# Altium schematic sheet LOGIC_FPGA.SchDoc
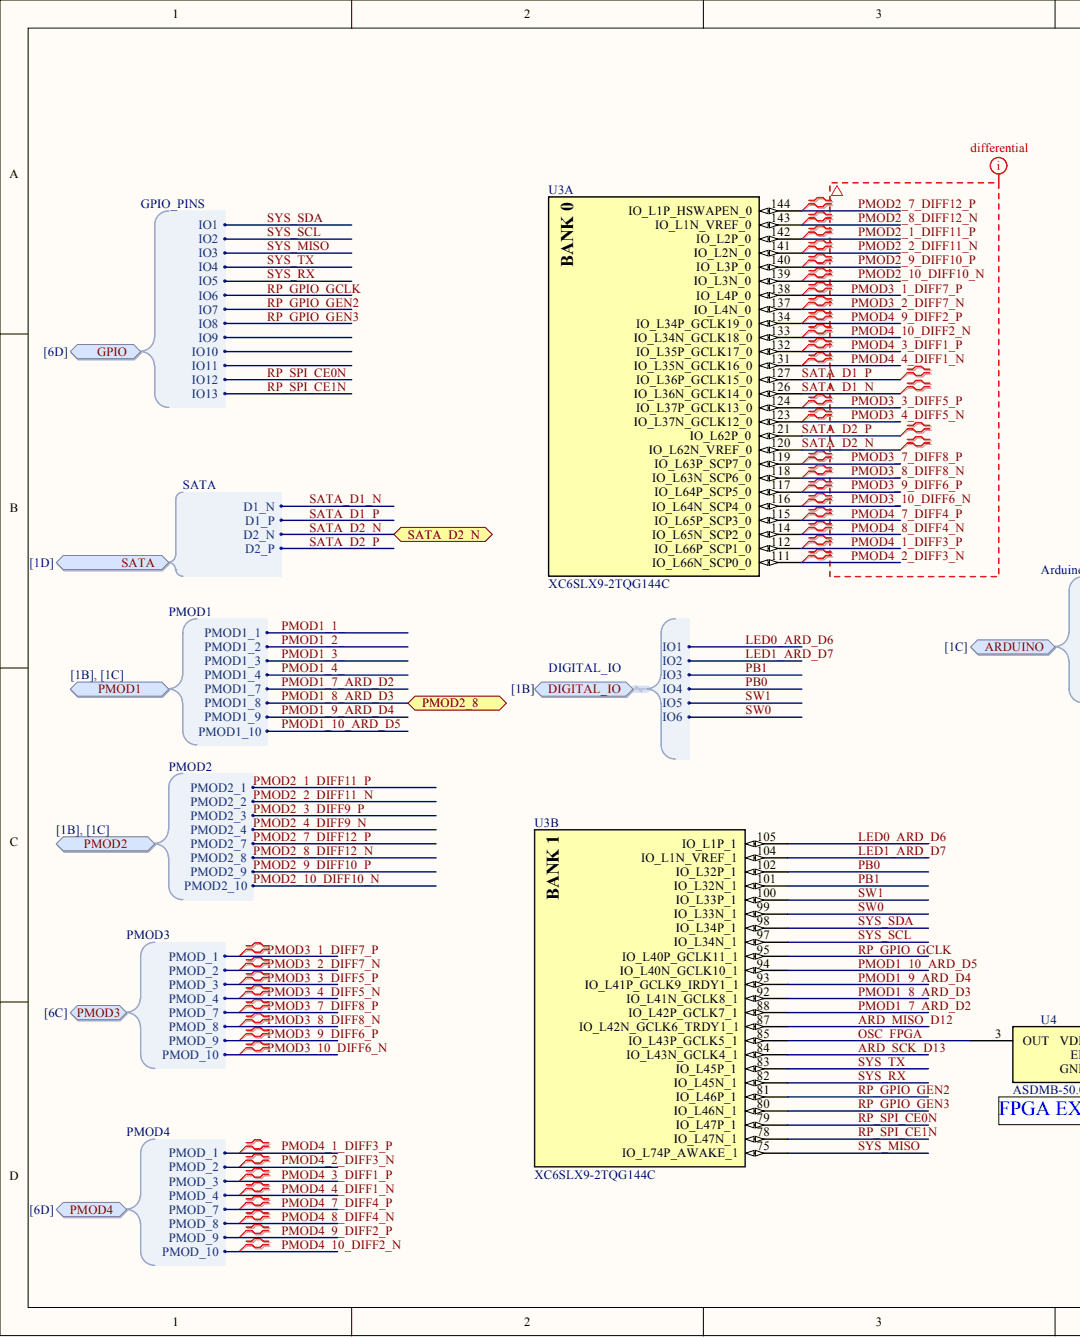
[diagram p0: LOGIC_FPGA.SchDoc, left]
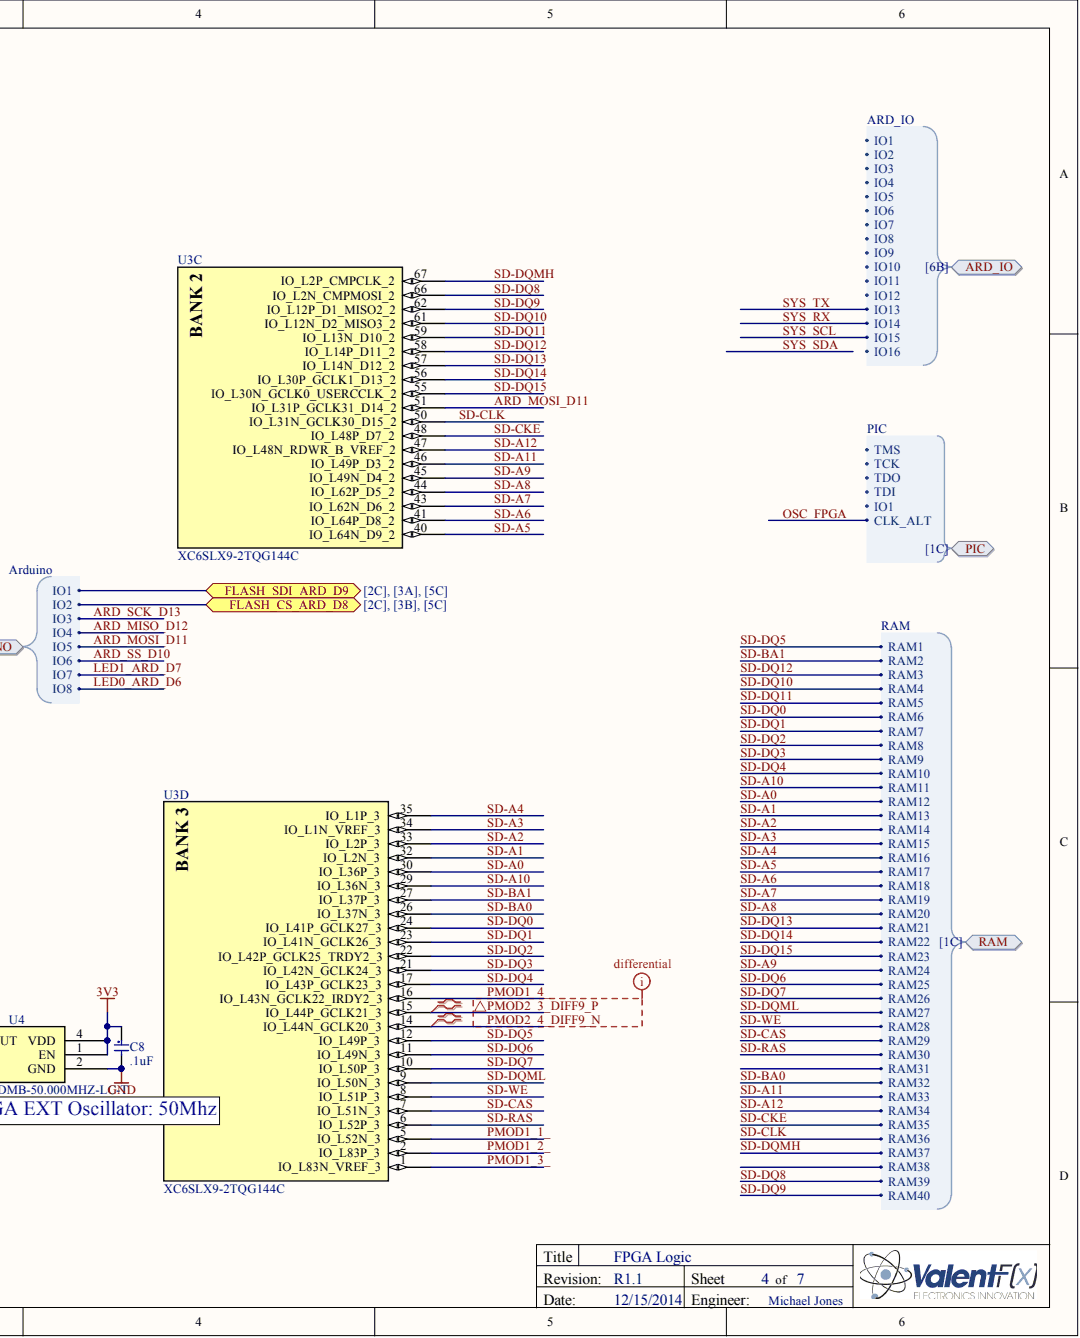
[diagram p1: LOGIC_FPGA.SchDoc, right]

## Parts
C8  .1uF  [c0603]
U3  XC6SLX9-2TQG144C  [TQ144_L]  (parts A,B,C,D)
U4  ASDMB-50.000MHZ-LC-T  [ASDMB - osc]

## Ports
ARD_IO, ARD_IO.IO1, ARD_IO.IO2, ARD_IO.IO3, ARD_IO.IO4, ARD_IO.IO5, ARD_IO.IO6, ARD_IO.IO7, ARD_IO.IO8, ARD_IO.IO9, ARD_IO.IO10, ARD_IO.IO11, ARD_IO.IO12, ARD_IO.IO13, ARD_IO.IO14, ARD_IO.IO15, ARD_IO.IO16, ARDUINO, ARDUINO.IO1, ARDUINO.IO2, ARDUINO.IO3, ARDUINO.IO4, ARDUINO.IO5, ARDUINO.IO6, ARDUINO.IO7, ARDUINO.IO8, DIGITAL_IO, DIGITAL_IO.IO1, DIGITAL_IO.IO2, DIGITAL_IO.IO3, DIGITAL_IO.IO4, DIGITAL_IO.IO5, DIGITAL_IO.IO6, FLASH_CS_ARD_D8, FLASH_SDI_ARD_D9, GPIO, GPIO.IO1, GPIO.IO2, GPIO.IO3, GPIO.IO4, GPIO.IO5, GPIO.IO6, GPIO.IO7, GPIO.IO8, GPIO.IO9, GPIO.IO10, GPIO.IO11, GPIO.IO12, GPIO.IO13, PIC, PIC.CLK_ALT, PIC.IO1, PIC.TCK, PIC.TDI, PIC.TDO, PIC.TMS, PMOD1, PMOD1.PMOD1_1, PMOD1.PMOD1_2, PMOD1.PMOD1_3, PMOD1.PMOD1_4, PMOD1.PMOD1_7, PMOD1.PMOD1_8, PMOD1.PMOD1_9, PMOD1.PMOD1_10, PMOD2, PMOD2.PMOD2_1, PMOD2.PMOD2_2, PMOD2.PMOD2_3, PMOD2.PMOD2_4, PMOD2.PMOD2_7, PMOD2.PMOD2_8, PMOD2.PMOD2_9, PMOD2.PMOD2_10, PMOD2_8, PMOD3, PMOD3.PMOD_1, PMOD3.PMOD_2, PMOD3.PMOD_3, PMOD3.PMOD_4, PMOD3.PMOD_7, PMOD3.PMOD_8, PMOD3.PMOD_9, PMOD3.PMOD_10, PMOD4, PMOD4.PMOD_1, PMOD4.PMOD_2, PMOD4.PMOD_3, PMOD4.PMOD_4, PMOD4.PMOD_7, PMOD4.PMOD_8, PMOD4.PMOD_9, PMOD4.PMOD_10, RAM, RAM.RAM1, RAM.RAM2, RAM.RAM3, RAM.RAM4, RAM.RAM5, RAM.RAM6, RAM.RAM7, RAM.RAM8, RAM.RAM9, RAM.RAM10, RAM.RAM11, RAM.RAM12, RAM.RAM13, RAM.RAM14, RAM.RAM15, RAM.RAM16, RAM.RAM17, RAM.RAM18, RAM.RAM19, RAM.RAM20, RAM.RAM21, RAM.RAM22, RAM.RAM23, RAM.RAM24, RAM.RAM25, RAM.RAM26, RAM.RAM27, RAM.RAM28, RAM.RAM29, RAM.RAM30, RAM.RAM31, RAM.RAM32, RAM.RAM33, RAM.RAM34, RAM.RAM35, RAM.RAM36, RAM.RAM37, RAM.RAM38, RAM.RAM39, RAM.RAM40, SATA, SATA.D1_N, SATA.D1_P, SATA.D2_N, SATA.D2_P, SATA_D2_N

## Nets
3V3: C8.1 U4.1(EN) U4.4(VDD)
GND: C8.2 U4.2(GND)
ARD_MISO_D12: U3.87(IO_L42N_GCLK6_TRDY1_1) ; port ARDUINO
ARD_MOSI_D11: U3.51(IO_L31P_GCLK31_D14_2) ; port ARDUINO
ARD_SCK_D13: U3.84(IO_L43N_GCLK4_1) ; port ARDUINO
LED0_ARD_D6: U3.105(IO_L1P_1) ; port ARDUINO, port DIGITAL_IO
LED1_ARD_D7: U3.104(IO_L1N_VREF_1) ; port ARDUINO, port DIGITAL_IO
OSC_FPGA: U3.85(IO_L43P_GCLK5_1) U4.3(OUT) ; port PIC
PB0: U3.102(IO_L32P_1) ; port DIGITAL_IO
PB1: U3.101(IO_L32N_1) ; port DIGITAL_IO
PMOD1_1_: U3.5(IO_L52N_3) ; port PMOD1
PMOD1_2_: U3.2(IO_L83P_3) ; port PMOD1
PMOD1_3_: U3.1(IO_L83N_VREF_3) ; port PMOD1
PMOD1_4_: U3.16(IO_L43N_GCLK22_IRDY2_3) ; port PMOD1
PMOD1_7_ARD_D2: U3.88(IO_L42P_GCLK7_1) ; port PMOD1
PMOD1_8_ARD_D3: U3.92(IO_L41N_GCLK8_1) ; port PMOD1, port PMOD2_8
PMOD1_9_ARD_D4: U3.93(IO_L41P_GCLK9_IRDY1_1) ; port PMOD1
PMOD1_10_ARD_D5: U3.94(IO_L40N_GCLK10_1) ; port PMOD1
PMOD2_1_DIFF11_P: U3.142(IO_L2P_0) ; port PMOD2
PMOD2_2_DIFF11_N: U3.141(IO_L2N_0) ; port PMOD2
PMOD2_3_DIFF9_P: U3.15(IO_L44P_GCLK21_3) ; port PMOD2
PMOD2_4_DIFF9_N: U3.14(IO_L44N_GCLK20_3) ; port PMOD2
PMOD2_7_DIFF12_P: U3.144(IO_L1P_HSWAPEN_0) ; port PMOD2
PMOD2_8_DIFF12_N: U3.143(IO_L1N_VREF_0) ; port PMOD2
PMOD2_9_DIFF10_P: U3.140(IO_L3P_0) ; port PMOD2
PMOD2_10_DIFF10_N: U3.139(IO_L3N_0) ; port PMOD2
PMOD3_1_DIFF7_P: U3.138(IO_L4P_0) ; port PMOD3
PMOD3_2_DIFF7_N: U3.137(IO_L4N_0) ; port PMOD3
PMOD3_3_DIFF5_P: U3.124(IO_L37P_GCLK13_0) ; port PMOD3
PMOD3_4_DIFF5_N: U3.123(IO_L37N_GCLK12_0) ; port PMOD3
PMOD3_7_DIFF8_P: U3.119(IO_L63P_SCP7_0) ; port PMOD3
PMOD3_8_DIFF8_N: U3.118(IO_L63N_SCP6_0) ; port PMOD3
PMOD3_9_DIFF6_P: U3.117(IO_L64P_SCP5_0) ; port PMOD3
PMOD3_10_DIFF6_N: U3.116(IO_L64N_SCP4_0) ; port PMOD3
PMOD4_1_DIFF3_P: U3.112(IO_L66P_SCP1_0) ; port PMOD4
PMOD4_2_DIFF3_N: U3.111(IO_L66N_SCP0_0) ; port PMOD4
PMOD4_3_DIFF1_P: U3.132(IO_L35P_GCLK17_0) ; port PMOD4
PMOD4_4_DIFF1_N: U3.131(IO_L35N_GCLK16_0) ; port PMOD4
PMOD4_7_DIFF4_P: U3.115(IO_L65P_SCP3_0) ; port PMOD4
PMOD4_8_DIFF4_N: U3.114(IO_L65N_SCP2_0) ; port PMOD4
PMOD4_9_DIFF2_P: U3.134(IO_L34P_GCLK19_0) ; port PMOD4
PMOD4_10_DIFF2_N: U3.133(IO_L34N_GCLK18_0) ; port PMOD4
RP_GPIO_GCLK: U3.95(IO_L40P_GCLK11_1) ; port GPIO
RP_GPIO_GEN2: U3.81(IO_L46P_1) ; port GPIO
RP_GPIO_GEN3: U3.80(IO_L46N_1) ; port GPIO
RP_SPI_CE0N: U3.79(IO_L47P_1) ; port GPIO
RP_SPI_CE1N: U3.78(IO_L47N_1) ; port GPIO
SATA_D1_N: U3.126(IO_L36N_GCLK14_0) ; port SATA
SATA_D1_P: U3.127(IO_L36P_GCLK15_0) ; port SATA
SATA_D2_N: U3.120(IO_L62N_VREF_0) ; port SATA, port SATA_D2_N
SATA_D2_P: U3.121(IO_L62P_0) ; port SATA
SD-A0: U3.30(IO_L36P_3) ; port RAM
SD-A1: U3.32(IO_L2N_3) ; port RAM
SD-A2: U3.33(IO_L2P_3) ; port RAM
SD-A3: U3.34(IO_L1N_VREF_3) ; port RAM
SD-A4: U3.35(IO_L1P_3) ; port RAM
SD-A5: U3.40(IO_L64N_D9_2) ; port RAM
SD-A6: U3.41(IO_L64P_D8_2) ; port RAM
SD-A7: U3.43(IO_L62N_D6_2) ; port RAM
SD-A8: U3.44(IO_L62P_D5_2) ; port RAM
SD-A9: U3.45(IO_L49N_D4_2) ; port RAM
SD-A10: U3.29(IO_L36N_3) ; port RAM
SD-A11: U3.46(IO_L49P_D3_2) ; port RAM
SD-A12: U3.47(IO_L48N_RDWR_B_VREF_2) ; port RAM
SD-BA0: U3.26(IO_L37N_3) ; port RAM
SD-BA1: U3.27(IO_L37P_3) ; port RAM
SD-CAS: U3.7(IO_L51N_3) ; port RAM
SD-CKE: U3.48(IO_L48P_D7_2) ; port RAM
SD-CLK: U3.50(IO_L31N_GCLK30_D15_2) ; port RAM
SD-DQ0: U3.24(IO_L41P_GCLK27_3) ; port RAM
SD-DQ1: U3.23(IO_L41N_GCLK26_3) ; port RAM
SD-DQ2: U3.22(IO_L42P_GCLK25_TRDY2_3) ; port RAM
SD-DQ3: U3.21(IO_L42N_GCLK24_3) ; port RAM
SD-DQ4: U3.17(IO_L43P_GCLK23_3) ; port RAM
SD-DQ5: U3.12(IO_L49P_3) ; port RAM
SD-DQ6: U3.11(IO_L49N_3) ; port RAM
SD-DQ7: U3.10(IO_L50P_3) ; port RAM
SD-DQ8: U3.66(IO_L2N_CMPMOSI_2) ; port RAM
SD-DQ9: U3.62(IO_L12P_D1_MISO2_2) ; port RAM
SD-DQ10: U3.61(IO_L12N_D2_MISO3_2) ; port RAM
SD-DQ11: U3.59(IO_L13N_D10_2) ; port RAM
SD-DQ12: U3.58(IO_L14P_D11_2) ; port RAM
SD-DQ13: U3.57(IO_L14N_D12_2) ; port RAM
SD-DQ14: U3.56(IO_L30P_GCLK1_D13_2) ; port RAM
SD-DQ15: U3.55(IO_L30N_GCLK0_USERCCLK_2) ; port RAM
SD-DQMH: U3.67(IO_L2P_CMPCLK_2) ; port RAM
SD-DQML: U3.9(IO_L50N_3) ; port RAM
SD-RAS: U3.6(IO_L52P_3) ; port RAM
SD-WE: U3.8(IO_L51P_3) ; port RAM
SW0: U3.99(IO_L33N_1) ; port DIGITAL_IO
SW1: U3.100(IO_L33P_1) ; port DIGITAL_IO
SYS_MISO: U3.75(IO_L74P_AWAKE_1) ; port GPIO
SYS_RX: U3.82(IO_L45N_1) ; port ARD_IO, port GPIO
SYS_SCL: U3.97(IO_L34N_1) ; port ARD_IO, port GPIO
SYS_SDA: U3.98(IO_L34P_1) ; port GPIO
SYS_TX: U3.83(IO_L45P_1) ; port ARD_IO, port GPIO
ARD_SS_D10: ; port ARDUINO
N00017: ; port RAM
N00018: ; port RAM
N00019: ; port PIC
N00020: ; port PIC
N00021: ; port PIC
N00022: ; port PIC
N00023: ; port PIC
N00024: ; port ARD_IO
N00025: ; port ARD_IO
N00026: ; port ARD_IO
N00027: ; port ARD_IO
N00028: ; port ARD_IO
N00029: ; port ARD_IO
N00030: ; port ARD_IO
N00031: ; port ARD_IO
N00032: ; port ARD_IO
N00033: ; port ARD_IO
N00034: ; port ARD_IO
N00035: ; port ARD_IO
N00036: ; port ARD_IO
N00037:
N00038: ; port ARDUINO, port FLASH_CS_ARD_D8
N00039: ; port ARDUINO, port FLASH_SDI_ARD_D9
N00040:
N00041: ; port GPIO
N00042: ; port GPIO
N00043: ; port GPIO

## Library data of the parts
C8 = C0603 - CL10F104ZA8NNNC: CAP CER 0.1UF 25V Y5V 0603; Manufacturer: Samsung Electro-Mechanics America, Inc; Accept Alternative: Yes; Capacitance: 0.1µF; Temperature Coefficient: Y5V (F); Tolerance: -20%, +80%; Applications: General Purpose; Category: Capacitors; Component Kind: Standard; ComponentLink1Description: http://www.samsungsem.com/servlet/FileDownload?type=spec&file=CL10F104ZA8NNNC.pdf; ComponentLink1URL: http://www.samsungsem.com/servlet/FileDownload?type=spec&file=CL10F104ZA8NNNC.pdf; Features: -; Height - Seated (Max): -; Lead Spacing: -; Lead Style: -; Library Name: mCapacitors.SchLib; Manufacturer Part Number: CL10F104ZA8NNNC; Mounting Type: Surface Mount, MLCC; Operating Temperature: -30°C ~ 85°C; Package / Case: 0603 (1608 Metric); Packaging: Cut Tape (CT); Pricing 1: 1=0.1, 10=0.017, 25=0.012, 50=0.009, 100=0.0076, 250=0.00624, 500=0.00536, 1000=0.00417 (USD); Ratings: -; RoHS: RoHS Compliant; Size / Dimension: 0.063" L x 0.031" W (1.60mm x 0.80mm); Stock 1: 400907; Supplier 1: Digi-Key; Supplier Part Number 1: 1276-1011-1-ND; Thickness (Max): 0.035" (0.90mm); Value: .1uF; Voltage - Rated: 25V
U3 = FPGA - XC6SLX9-2TQG144C: IC FPAG SPARTAN 6 9K 144TQFP; Manufacturer: Xilinx Inc; Number of I /O: 102; Number of LABs/CLBs: 715; Number of Logic Elements/Cells: 9152; Total RAM Bits: 589824; Voltage - Supply: 1.14 V ~ 1.26 V; Category: Integrated Circuits (ICs); Component Kind: Standard; ComponentLink1Description: http://www.xilinx.com/support/documentation/data_sheets/ds160.pdf; ComponentLink1URL: http://www.xilinx.com/support/documentation/data_sheets/ds160.pdf; Library Name: mIC.SchLib; Manufacturer Part Number: XC6SLX9-2TQG144C; Mounting Type: Surface Mount; Number of Gates: -; Operating Temperature: 0°C ~ 85°C; Package / Case: 144-LQFP; Pricing 1: 1=12.80,1=11.05,1000=9.59 (USD); RoHS: RoHS Compliant; Stock 1: 994; Supplier 1: America II Electronics; Supplier 2: Digi-Key; Supplier Device Package: 144-TQFP (20x20); Supplier Part Number 1: 122-1745-ND; Value: XC6SLX9-2TQG144C
U4 = ASDMB-50.000MHZ-LC-T: OSC MEMS 50.000 MHZ SMD; Manufacturer: Abracon Corporation; Current - Supply (Disable) (Max): 15µA; Current - Supply (Max): 16mA; Frequency: 50MHz; Frequency Stability: ±50ppm; Function: Tri-State (Output Enable); Output: CMOS; Voltage - Supply: 1.8 V ~ 3.3 V; Category: Crystals and Oscillators; Component Kind: Standard; ComponentLink1Description: http://www.abracon.com/Oscillators/ASDMB.pdf; ComponentLink1URL: http://www.abracon.com/Oscillators/ASDMB.pdf; Height: 0.035" (0.90mm); Library Name: eIC.SchLib; Manufacturer Part Number: ASDMB-50.000MHZ-LC-T; Mounting Type: Surface Mount; Operating Temperature: -40°C ~ 85°C; Package / Case: 4-SMD, No Lead (DFN, LCC); Packaging: Cut Tape (CT); Pricing 1: 1=1.69, 10=1.463, 50=1.2826, 100=1.0125, 500=0.855 (USD); RoHS: RoHS Compliant; Size / Dimension: 0.098" L x 0.079" W (2.50mm x 2.00mm); Stock 1: 967; Supplier 1: Digi-Key; Supplier Part Number 1: 535-11738-1-ND; Type: MEMS (Silicon); Value: ASDMB-50.000MHZ-LC-T
